# Altium schematic sheet EMG_PART.SchDoc(EMG_PART)
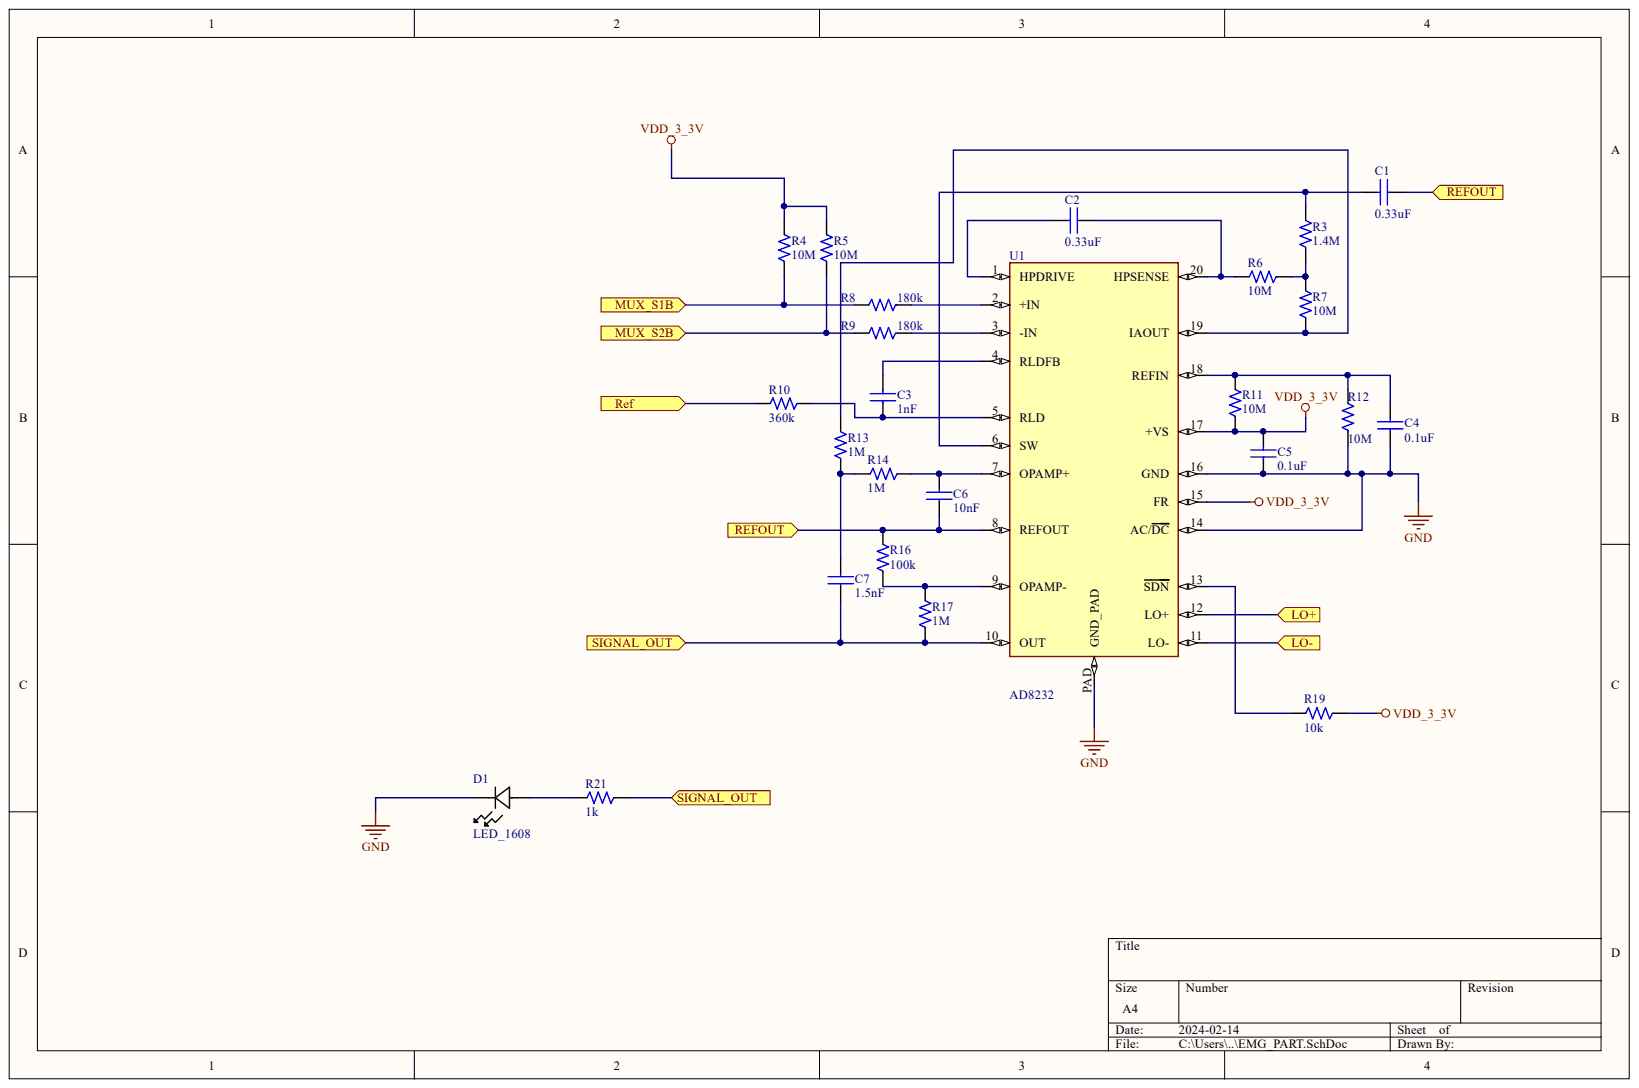
[diagram p0: EMG_PART.SchDoc]

## Parts
C1  0.33uF  [C1608]
C2  0.33uF  [C1608]
C3  1nF  [C1608]
C4  0.1uF  [C1608]
C5  0.1uF  [C1608]
C6  10nF  [C1608]
C7  1.5nF  [C1608]
D1  LED_1608  [LED_597-5312_DIA]
R3  1.4M  [R1608]
R4  10M  [R1608]
R5  10M  [R1608]
R6  10M  [R1608]
R7  10M  [R1608]
R8  180k  [R1608]
R9  180k  [R1608]
R10  360k  [R1608]
R11  10M  [R1608]
R12  10M  [R1608]
R13  1M  [R1608]
R14  1M  [R1608]
R16  100k  [R1608]
R17  1M  [R1608]
R19  10k  [R1608]
R21  1k  [R1608]
U1  AD8232  [LFCSP_20]

## Ports
LO+, LO-, MUX_S1B, MUX_S2B, REF, REFOUT, SIGNAL_OUT

## Nets
GND: C4.1 C5.1 D1.1 R12.1 U1.14(AC/DC) U1.16(GND) U1.PAD(GND_PAD)
VDD_3_3V: C5.2 R4.2 R5.2 R11.1 R19.1 U1.15(FR) U1.17(+VS)
MUX_S1B: R4.1 R8.2 ; port MUX_S1B
MUX_S2B: R5.1 R9.2 ; port MUX_S2B
REFOUT: C1.1 C6.1 R16.2 U1.8(REFOUT) ; port REFOUT
SIGNAL_OUT: C7.1 R17.1 R21.1 U1.10(OUT) ; port SIGNAL_OUT
NetC1_2: C1.2 R3.2 U1.6(SW)
NetC2_1: C2.1 R6.2 U1.20(HPSENSE)
NetC2_2: C2.2 U1.1(HPDRIVE)
NetC3_1: C3.1 R10.1 U1.5(RLD)
NetC3_2: C3.2 U1.4(RLDFB)
NetC4_2: C4.2 R11.2 R12.2 U1.18(REFIN)
NetC6_2: C6.2 R14.2 U1.7(OPAMP+)
NetC7_2: C7.2 R13.1 R14.1
NetD1_2: D1.2 R21.2
NetR3_1: R3.1 R6.1 R7.2
NetR7_1: R7.1 R13.2 U1.19(IAOUT)
NetR8_1: R8.1 U1.2(+IN)
NetR9_1: R9.1 U1.3(-IN)
NetR10_2: R10.2 ; port REF
NetR16_1: R16.1 R17.2 U1.9(OPAMP-)
NetR19_2: R19.2 U1.13(SDN)
NetU1_11: U1.11(LO-)
NetU1_12: U1.12(LO+)

## Library data of the parts
C1-C7 = Capacitor: Component Kind: Standard; Description: Capacitor; Library Name: Dong_Parts.SchLib
D1 = LED_1608: No Description Available; Manufacturer_Part_Number: 597-5312-40-7NF; Mfr_Name: Dialight; Type: DEV; Component Kind: Standard; RefDes: RefDes; Copyright: Copyright (C) 2023 Ultra Librarian. All rights reserved.; Library Name: Dong_Parts.IntLib
R3-R14 R16 R17 R19 R21 = Resistor: Component Kind: Standard; Description: Resistor; Library Name: Dong_Parts.SchLib
U1 = AD8232: AD8232 Analog Front End Evaluation Board; MF: Analog Devices, Inc.; Availability: In Stock; Check_prices: https://www.snapeda.com/parts/AD8232/Analog+Devices+Inc./view-part/?ref=eda; Component Kind: Standard; MP: AD8232; Package: None; Price: None; Purchase-URL: https://pricing.snapeda.com/search/part/AD8232/?ref=eda; SnapEDA_Link: https://www.snapeda.com/parts/AD8232/Analog+Devices+Inc./view-part/?ref=snap; Library Name: Dong_Parts.SchLib
